# Altium schematic sheet SAM_Dispenser_2.SchDoc
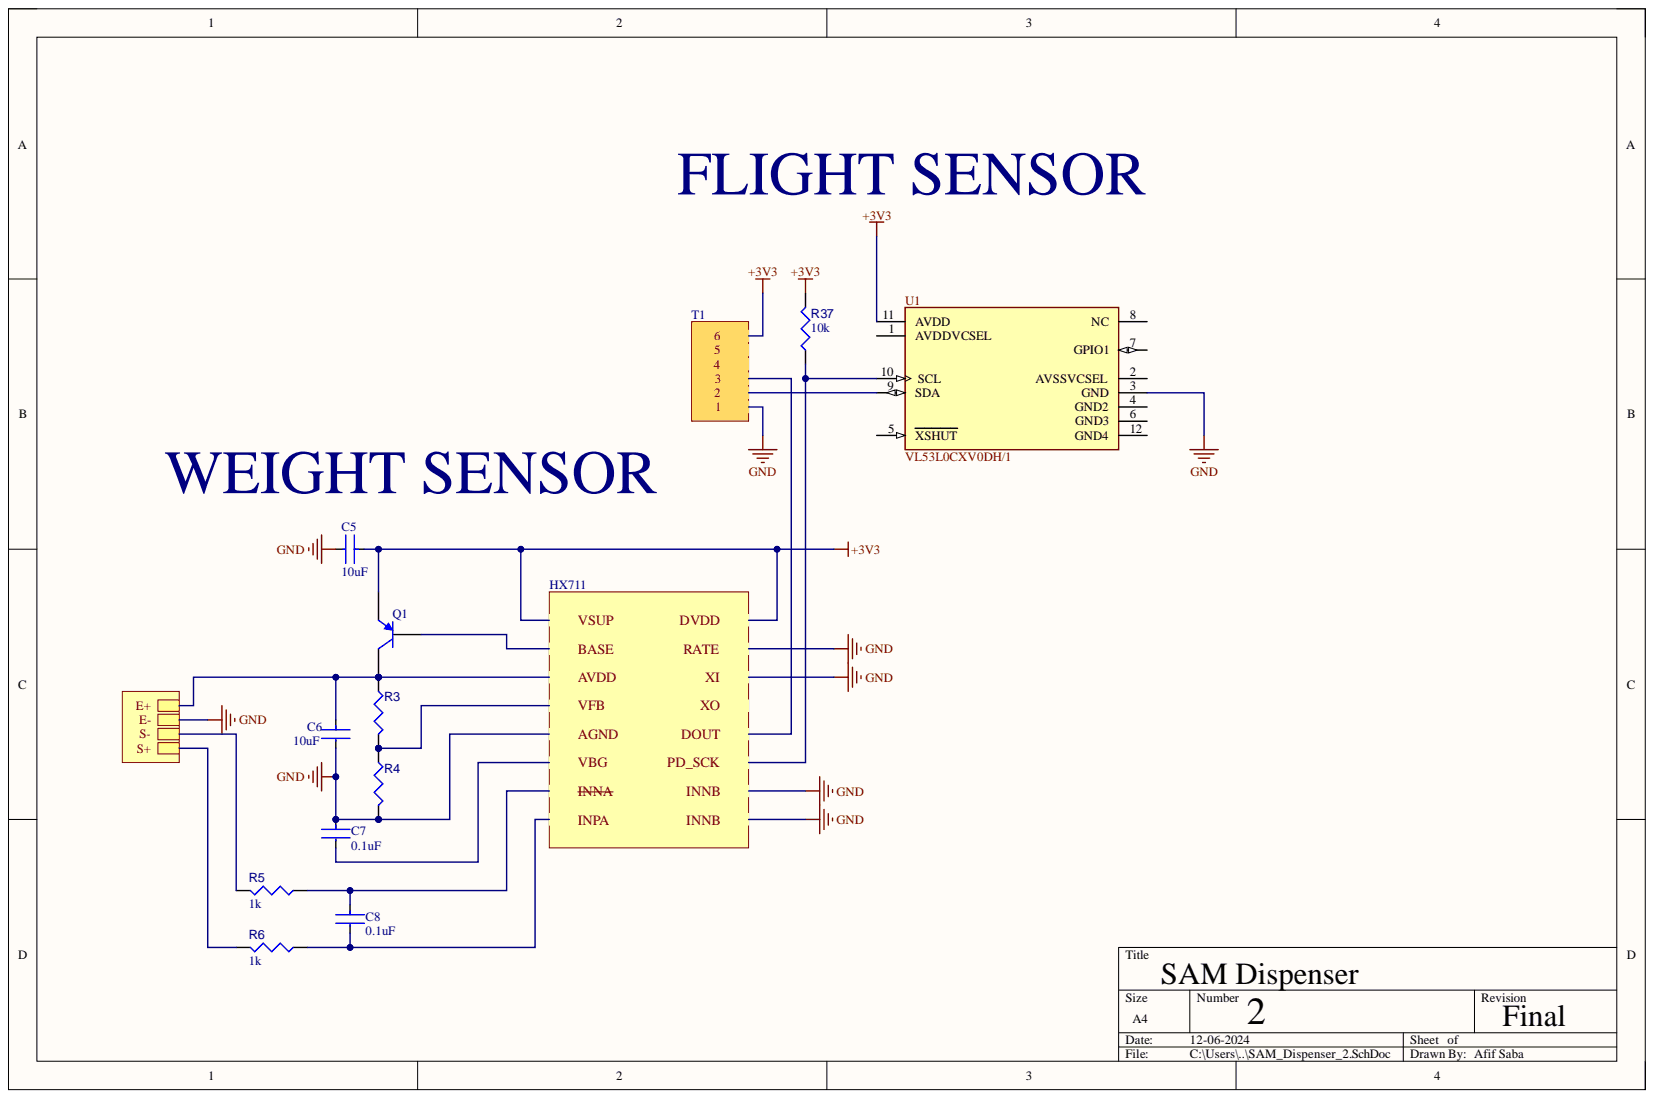
[diagram p0: SAM_Dispenser_2.SchDoc]

## Parts
C5  Parameter 1: 10uF  [4.7uF400V]  (hidden comment: 475CKE400M)
C6  Parameter 1: 10uF  [4.7uF400V]  (hidden comment: 475CKE400M)
C7  Parameter 1: 0.1uF  [4.7uF400V]  (hidden comment: 475CKE400M)
C8  Parameter 1: 0.1uF  [4.7uF400V]  (hidden comment: 475CKE400M)
Q1  PN2907ABU  [FAIR-TO-92]  (value not drawn)
R3  3KR2F  [RESC0402(1005)_L]  (value not drawn)
R4  3KR2F  [RESC0402(1005)_L]  (value not drawn)
R5  R34: 1k  [RESC0402(1005)_L]  (hidden comment: 3KR2F)
R6  R34: 1k  [RESC0402(1005)_L]  (hidden comment: 3KR2F)
R37  R34: 10k  [RESC0402(1005)_L]  (hidden comment: 3KR2F)
U1  VL53L0CXV0DH/1  [FP-LGA-12-MFG]

## Nets
3: C5.1 Q1.1 R37.2 U1.11(AVDD)
GND: C5.2 C6.2 C7.1 R4.2 U1.3(GND)
NetC6_1: C6.1 Q1.3 R3.1
NetC8_1: C8.1 R5.1
NetC8_2: C8.2 R6.1
NetR3_2: R3.2 R4.1
NetR37_1: R37.1 U1.10(SCL)
4:
5:
XO:

Not connected: C7 2; Q1 2; R5 2; R6 2; U1 1(AVDDVCSEL) 2(AVSSVCSEL) 4(GND2) 5(XSHUT) 6(GND3) 7(GPIO1) 8(NC) 9(SDA) 12(GND4)

## Library data of the parts
C5-C8 = CMP-003-000022-1: 4.7 µF 400 V Aluminum Electrolytic Capacitors Radial, Can 70.547Ohm @ 120Hz 2000 Hrs @ 105°C; Parameter 2: 00V; Component Kind: Standard; Design Item ID: 475CKE400M; Source: NewVoltageReg.SCHLIB; Library Name: UNB Workspace
Q1 = CMP-013-00010-2: BJT PNP General Purpose Transistor, 60 V, 800 mA, -55 to 150 degC, 3-Pin TO-92, RoHS, Bulk; Manufacturer: Fairchild Semiconductor; Elements: 1; Frequency: 200MHz; hFE Min: 100; Power: 625mW; Vce Max: 1.6V; Case/Package: TO-226-3; Component Kind: Standard; Datasheet URL: http://datasheet.octopart.com/PN2907ABU-Fairchild-Semiconductor-datasheet-22973435.pdf; Datasheet Version: Rev. 1.1.2, 01/2014; Max Operating Temperature: 150°C; Min Operating Temperature: -55°C; Mounting Technology: TH; Package Description: 3-Pin Transistor Outline Package, Body Dia 4.79 mm, Pitch 1.27 mm; Package Quantity: 1000; Package Reference: TO-92; Packaging: Bulk; Pins: 3; RoHS Compliant: True; Library Name: UNB Workspace
R3 R4 = CMP-009-00099-1: 3K 0.063W 1% 0402 (1005 Metric)  SMD; Power: 63mW; R34: 1k; Case/Package: 0402; Component Kind: Standard; Mounting Technology: SMT; Pins: 2; Tolerance: 1%; Library Name: UNB Workspace
R5 R6 R37 = CMP-009-00099-1: 3K 0.063W 1% 0402 (1005 Metric)  SMD; Power: 63mW; Case/Package: 0402; Component Kind: Standard; Mounting Technology: SMT; Pins: 2; Tolerance: 1%; Library Name: UNB Workspace
U1 = CMP-2000-07484-2: SENSOR OPTICAL 200CM I2C; Manufacturer: STMicroelectronics; Max Supply Voltage: 3.5V; Min Supply Voltage: 1.6V; Operating Supply Current: 0.016-19mA; Automotive: No; Category: IC; Component Kind: Standard; ComponentLink2Description: Datasheet; ComponentLink2URL: https://www.st.com/resource/en/datasheet/vl53l0x.pdf; Device Class L1: Sensors; Device Class L2: Optical Sensors; Device Class L3: unset; Digikey Description: SENSOR OPTICAL 200CM I2C; Height: 1.05mm; Lead Free: Yes; Library Name: Altium Content Vault; Manufacturer Part Number: VL53L0CXV0DH/1; Octopart: https://octopart.com/vl53l0cxv0dh%2F1-stmicroelectronics-71168165; Package: LGA-12; RoHS: TRUE; Sensor Output: I2C; Temperature: -20°C to +70°C; Temperature Range High: +70°C; Temperature Range Low: -20°C
